# Altium schematic sheet ct_back.SchDoc
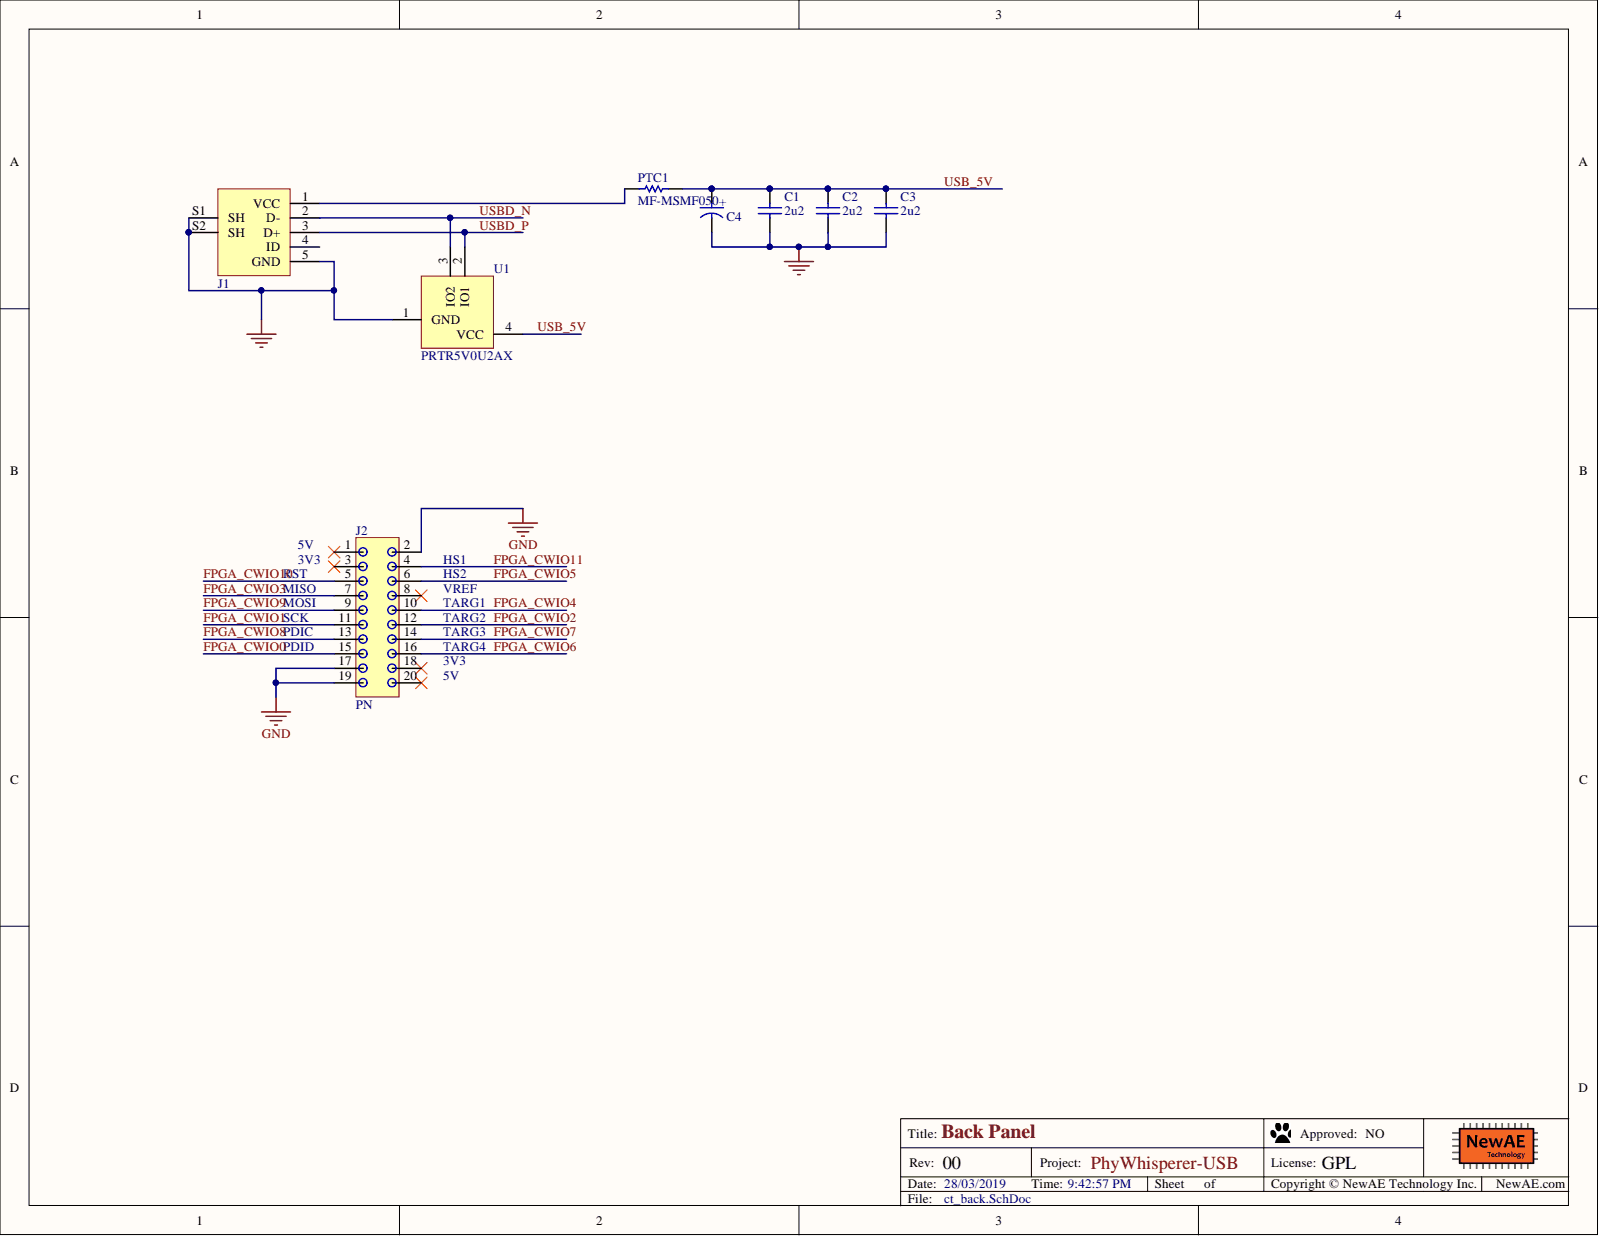
[diagram p0: ct_back.SchDoc]

## Parts
C1  2u2  [0603]
C2  2u2  [0603]
C3  2u2  [0603]
C4  680uF  [Cap_16v_680uF]  (value not drawn)
J1  USB_MicroB_Jack  [ZX62D-B-5P8(30)]  (value not drawn)
J2  PN  [CON-20HDR-EDGEMOUNT]
PTC1  MF-MSMF050  [BOUR-MF-MSMF050-2_V]
U1  PRTR5V0U2AX  [SOT143B]

## Nets
GND: C1.2 C2.2 C3.2 C4.2 J1.5(GND) J1.S1(SH) J1.S2(SH) J2.2 J2.17 J2.19 U1.1(GND)
FPGA_CWIO0: J2.15
FPGA_CWIO1: J2.11
FPGA_CWIO2: J2.12
FPGA_CWIO3: J2.7
FPGA_CWIO4: J2.10
FPGA_CWIO5: J2.6
FPGA_CWIO6: J2.16
FPGA_CWIO7: J2.14
FPGA_CWIO8: J2.13
FPGA_CWIO9: J2.9
FPGA_CWIO10: J2.5
FPGA_CWIO11: J2.4
USB_5V: C1.1 C2.1 C3.1 C4.1 PTC1.2 U1.4(VCC)
USBD_N: J1.2(D-) U1.3(IO2)
USBD_P: J1.3(D+) U1.2(IO1)
NetJ1_1: J1.1(VCC) PTC1.1

Not connected: J1 4(ID); J2 1 3 8 18 20

## Library data of the parts
C1-C3 = CCHIP-2u2-0603: 2u2, 0603; Manufacture 1: Samsung; Manufacture Part Number 1: CL10B225KP8NNNC; Case-Metric: 1608; Component Group: Capacitor; Database Table Name: main; Rated Voltage: 10VDC; Case-EIA: 0603; Component Kind: Standard; Component Type: Standard; Library Name: NewAE_AltiumLib.DBLib; Mounting Technology: SMT; Part Number: CCHIP-2u2-0603; Pin Count: 2; Supplier 1: Digi-Key; Supplier Part Number 1: 1276-1134-2-ND; Value: 2u2
C4 = Cap_16v_680uF: Aluminum electrolytic 680uF, 8x16mm, radial; Component Group: Capacitor; Database Table Name: main; PP Material Stack: 0; Component Kind: Standard; Component Type: Standard; Library Name: NewAE_AltiumLib.DBLib; Mounting Technology: Through-hole; Part Number: Cap_16v_680uF; Pin Count: 2; PP Rotation: 0; Value: 680uF
J1 = ZX62D-B-5P8(30): CONN RCPT USB MICRO B SMD; Manufacture 1: Hirose Electric Co Ltd; Component Group: Connector; Database Table Name: main; PP Material Stack: 0; Component Kind: Standard; Component Type: Standard; Library Name: NewAE_AltiumLib.DBLib; Manufacture Part Number 1: ZX62D-B-5P8(30); Mounting Technology: Through-hole; Part Number: ZX62D-B-5P8(30); Pin Count: 5; PP Rotation: 0; RoHS: Yes; Supplier 1: Digi-Key; Supplier Part Number 1: H125272CT-ND
J2 = CON20HDR-EDGE: Component Group: Connector; Database Table Name: main; PP Material Stack: 0; Component Kind: Standard; Component Type: Standard; Library Name: NewAE_AltiumLib.DBLib; Part Number: CON20HDR-EDGE; Pin Count: 20; PP Rotation: 0
PTC1 = CMP-0280-00002-2: MF-MSMF Series - PTC Resettable Fuse, 15 V Max, 0.15 Ohm Min, -40 to 85 degC, RoHS, Reel; Manufacturer: Bourns; Current Rating (Max): 100 A; Hold Current: 0.5 A; Trip Current: 1 A; Voltage DC (Max): 15 V; Component Kind: Standard; ComponentLink1Description: Manufacturer URL; ComponentLink1URL: http://www.bourns.com/; ComponentLink2Description: Datasheet; ComponentLink2URL: http://www.bourns.com/data/global/pdfs/mfmsmf.pdf; ComponentLink3Description: Package Specification; ComponentLink3URL: http://www.bourns.com/data/global/pdfs/mfmsmf.pdf; DatasheetVersion: Rev. AD; Library Name: Altium Content Vault; Mounting Technology: Surface Mount; Operating Temperature Range: -40 to 85 degC; PackageDescription: 2-Pin SMD, Body 4.55 x 3.24 mm; PackageReference: MF-MSMF050; Packing: Reel; PartNumber: MF-MSMF050; Resistance (Min): 0.15 Ohm; RoHS: TRUE; Signal Integrity: Guessed Model; Supplier 1: Digi-Key; Supplier Part Number 1: MF-MSMF050-2CT-ND
U1 = PRTR5V0U2AX: USB 2.0 high-speed ESD; Component Group: Diode; Database Table Name: main; Component Kind: Standard; Component Type: Standard; Library Name: NewAE_AltiumLib.DBLib; Part Number: PRTR5V0U2AX; Pin Count: 4; Supplier 1: Digi-Key; Supplier Part Number 1: 568-7445-1-ND
